# Altium schematic sheet Airlock_Capacitive.SchDoc(Airlock_Capacitive)
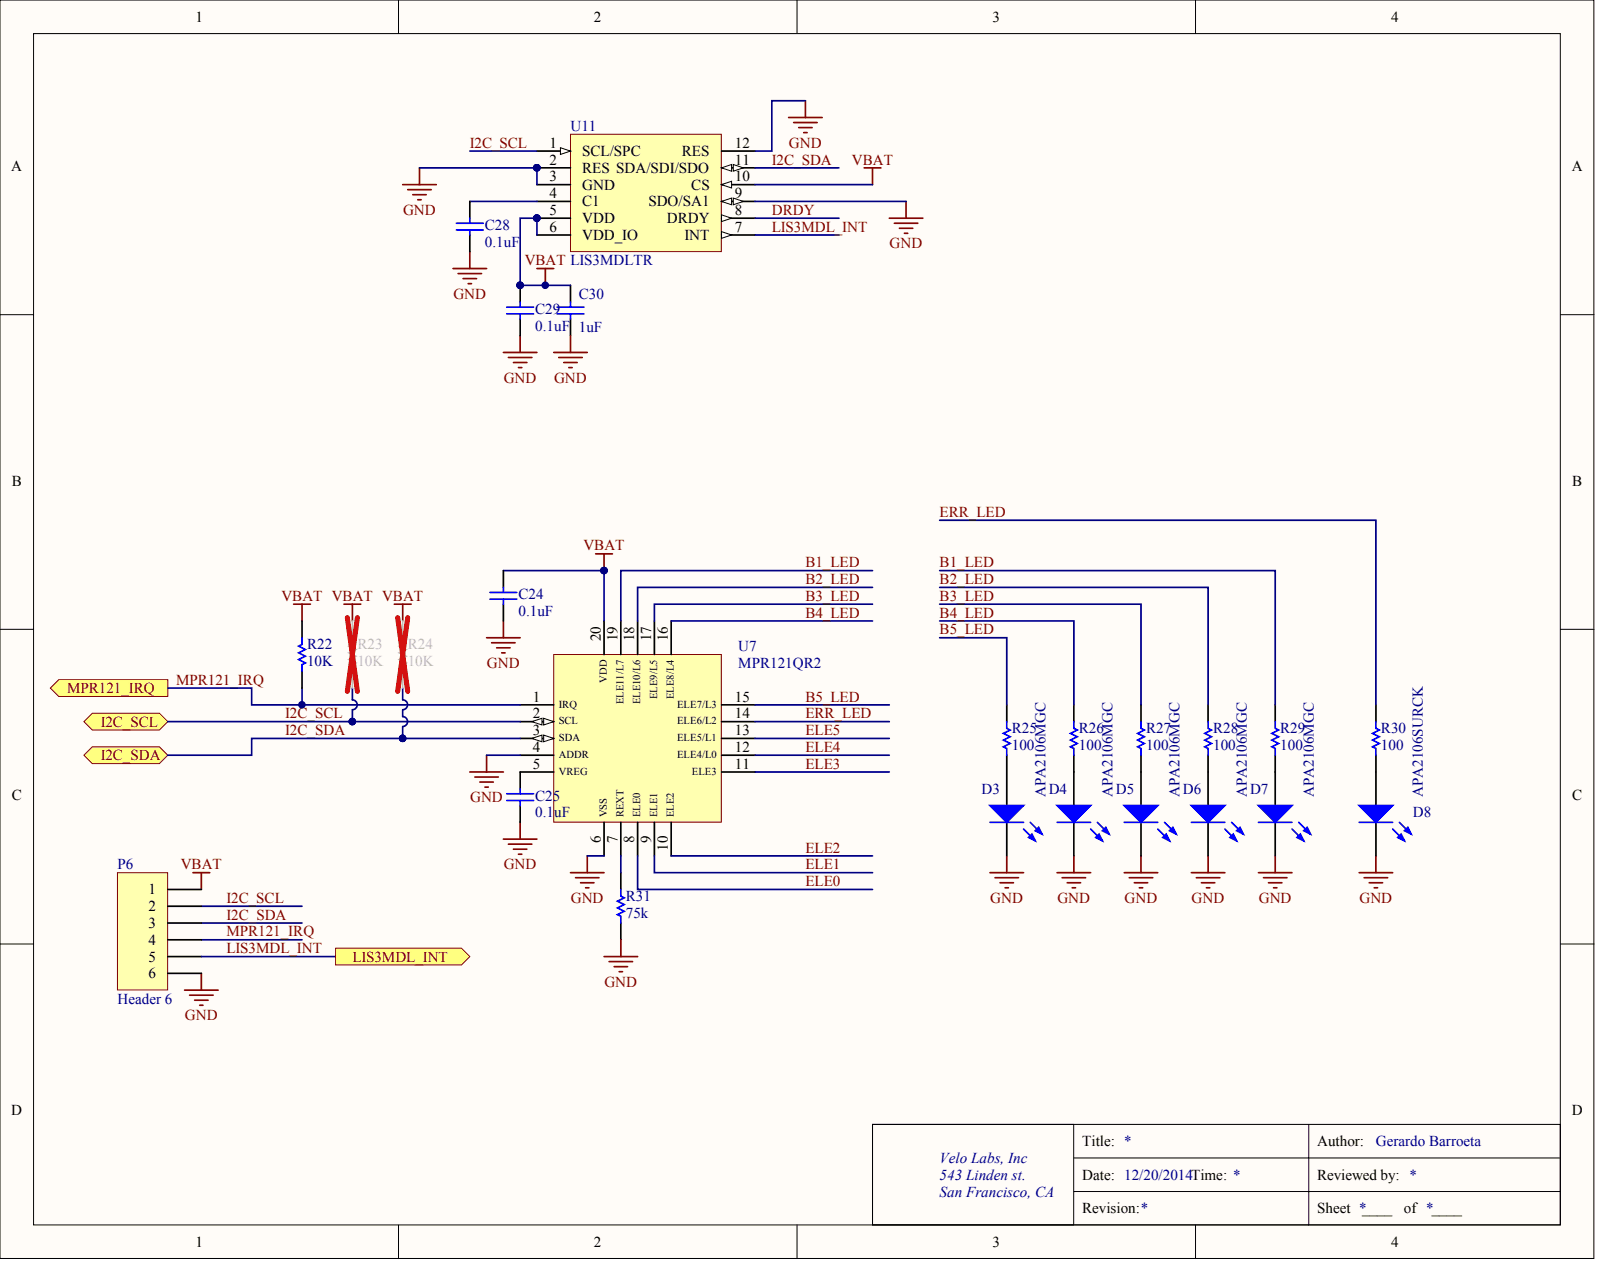
[diagram p0: Airlock_Capacitive.SchDoc]

## Parts
C24  value 0.1uF  (hidden comment: X7R, 16V, 10%)
C25  value 0.1uF  (hidden comment: X7R, 16V, 10%)
C28  value 0.1uF  (hidden comment: X7R, 16V, 10%)
C29  value 0.1uF  (hidden comment: X7R, 16V, 10%)
C30  value 1uF  (hidden comment: X5R, 6.3V 10%)
D3  APA2106MGC
D4  APA2106MGC
D5  APA2106MGC
D6  APA2106MGC
D7  APA2106MGC
D8  APA2106SURCK
P6  Header 6
R22  1%  value 10K
R23  1%  value 10K
R24  1%  value 10K
R25  value 100  (hidden comment: 5% 1/10W)
R26  value 100  (hidden comment: 5% 1/10W)
R27  value 100  (hidden comment: 5% 1/10W)
R28  value 100  (hidden comment: 5% 1/10W)
R29  value 100  (hidden comment: 5% 1/10W)
R30  value 100  (hidden comment: 5% 1/10W)
R31  value 75k  (hidden comment: 5% 1/10W)
U7  MPR121QR2
U11  LIS3MDLTR

## Ports
I2C_SCL, I2C_SDA, LIS3MDL_INT, MPR121_IRQ

## Nets
GND: C24.2 C25.2 C28.2 C29.2 C30.1 D3.2 D4.2 D5.2 D6.2 D7.2 D8.2 P6.6 R31.1 U7.4(ADDR) U7.6(VSS) U11.2(RES) U11.3(GND) U11.9(SDO/SA1) U11.12(RES)
VBAT: C24.1 C29.1 C30.2 P6.1 R22.2 R23.2 R24.2 U7.20(VDD) U11.5(VDD) U11.6(VDD_IO) U11.10(CS)
B1_LED: R29.1 U7.19(ELE11/L7)
B2_LED: R28.1 U7.18(ELE10/L6)
B3_LED: R27.1 U7.17(ELE9/L5)
B4_LED: R26.1 U7.16(ELE8/L4)
B5_LED: R25.1 U7.15(ELE7/L3)
DRDY: U11.8(DRDY)
ELE0: U7.8(ELE0)
ELE1: U7.9(ELE1)
ELE2: U7.10(ELE2)
ELE3: U7.11(ELE3)
ELE4: U7.12(ELE4/L0)
ELE5: U7.13(ELE5/L1)
ERR_LED: R30.1 U7.14(ELE6/L2)
I2C_SCL: P6.2 R23.1 U7.2(SCL) U11.1(SCL/SPC) ; port I2C_SCL
I2C_SDA: P6.3 R24.1 U7.3(SDA) U11.11(SDA/SDI/SDO) ; port I2C_SDA
LIS3MDL_INT: P6.5 U11.7(INT) ; port LIS3MDL_INT
MPR121_IRQ: P6.4 R22.1 U7.1(IRQ) ; port MPR121_IRQ
NetC25_1: C25.1 U7.5(VREG)
NetC28_1: C28.1 U11.4(C1)
NetD3_1: D3.1 R25.2
NetD4_1: D4.1 R26.2
NetD5_1: D5.1 R27.2
NetD6_1: D6.1 R28.2
NetD7_1: D7.1 R29.2
NetD8_1: D8.1 R30.2
NetR31_2: R31.2 U7.7(REXT)

## Library data of the parts
C24 C25 C28 C29 = (no symbol name): Manufacturer: Murata Electronics North America; Manufacturer Part Number: GRM155R71C104KA88D; Description 1: CAP CER 0.1UF 16V 10% X7R 0402; Capacitance: 0.1µF; Supplier 1: Digi-Key; Supplier Part Number 1: 490-3261-1-ND; RoHS: RoHS Compliant; Category: Capacitors; Stock 1: 16410340; Pricing 1: 1=0.1, 10=0.015, 50=0.0082, 100=0.0069, 250=0.00564, 500=0.00482, 1000=0.00375, 5000=0.00313 (USD); Packaging: Cut Tape (CT); Tolerance: ±10%; Voltage - Rated: 16V; Temperature Coefficient: X7R; Mounting Type: Surface Mount, MLCC; Operating Temperature: -55°C ~ 125°C; Applications: General Purpose; Ratings: -; Package / Case: 0402 (1005 Metric); Size / Dimension: 0.039" L x 0.020" W (1.00mm x 0.50mm); Height - Seated (Max): -; Thickness (Max): 0.022" (0.55mm); Lead Spacing: -; Features: -; Lead Style: -; ComponentLink1URL: http://www.murata.com/~/media/webrenewal/support/library/catalog/products/capacitor/mlcc/c02e.ashx; ComponentLink1Description: http://www.murata.com/~/media/webrenewal/support/library/catalog/products/capacitor/mlcc/c02e.ashx
C30 = (no symbol name): Manufacturer: Murata Electronics North America; Manufacturer Part Number: GRM155R60J105KE19D; Description 1: CAP CER 1UF 6.3V 10% X5R 0402; Capacitance: 1.0µF; Applications: General Purpose; Supplier 1: Digi-Key; Supplier Part Number 1: 490-1320-1-ND; RoHS: RoHS Compliant; Category: Capacitors; Stock 1: 2947181; Pricing 1: 1=0.1, 10=0.035, 50=0.0192, 100=0.0163, 250=0.01332, 500=0.01138, 1000=0.0087, 5000=0.00725 (USD); Packaging: Cut Tape (CT); Tolerance: ±10%; Voltage - Rated: 6.3V; Temperature Coefficient: X5R; Mounting Type: Surface Mount, MLCC; Operating Temperature: -55°C ~ 85°C; Ratings: -; Package / Case: 0402 (1005 Metric); Size / Dimension: 0.039" L x 0.020" W (1.00mm x 0.50mm); Height - Seated (Max): -; Thickness (Max): 0.022" (0.55mm); Lead Spacing: -; Features: -; Lead Style: -; ComponentLink1URL: http://www.murata.com/~/media/webrenewal/support/library/catalog/products/capacitor/mlcc/c02e.ashx; ComponentLink1Description: http://www.murata.com/~/media/webrenewal/support/library/catalog/products/capacitor/mlcc/c02e.ashx
D3-D7 = (no symbol name): Manufacturer: Kingbright; Description 1: Standard LEDs - SMD Green 570nm Water Clear 80mcd; Illumination Color: Green; Forward Current: 20 mA; Forward Voltage: 2.1 V; LED Size: 2.1 mm x 0.6 mm x 1 mm; Luminous Intensity: 80 mcd; Viewing Angle: 120 deg; Wavelength/Color Temperature: 570 nm; Supplier 1: Mouser; Manufacturer Part Number: APA2106MGC; RoHS: RoHS Compliant; Category: Standard LEDs - SMD; Stock 1: 1095; Pricing 1: 1=0.675, 10=0.423, 100=0.346, 1000=0.251, 2000=0.211, 10000=0.203, 24000=0.193, 50000=0.192 (AUD); Package / Case: 2.1 mm x 0.6 mm x 1 mm; Packaging: Reel; Maximum Operating Temperature: + 85 C; Minimum Operating Temperature: - 40 C; Product Category: LED SMD Standard; Standard Pack Qty: 2000; RoHS - Mouser: Y; Minimum Order Quantity: 1; Reeling: True; ComponentLink1URL: http://www.kingbrightusa.com/images/catalog/SPEC/APA2106MGC.pdf; ComponentLink1Description: http://www.kingbrightusa.com/images/catalog/SPEC/APA2106MGC.pdf; Supplier Part Number 1: 604-APA2106MGC
D8 = (no symbol name): Manufacturer: Kingbright; Description 1: Standard LEDs - SMD Hyper Red R.A. 635nm, 250mcd; Illumination Color: Red; Forward Current: 20 mA; Forward Voltage: 1.95 V; LED Size: 2.1 mm x 0.6 mm x 1 mm; Luminous Intensity: 250 mcd; Viewing Angle: 120 deg; Wavelength/Color Temperature: 630 nm; Supplier 1: Mouser; Manufacturer Part Number: APA2106SURCK; RoHS: RoHS Compliant; Category: Standard LEDs - SMD; Stock 1: 0; Pricing 1: 1=0.324, 10=0.198, 100=0.147, 1000=0.120, 2000=0.108, 10000=0.099, 24000=0.089, 50000=0.084, 100000=0.080 (AUD); Package / Case: 2.1 mm x 0.6 mm x 1 mm; Packaging: Reel; Maximum Operating Temperature: + 85 C; Minimum Operating Temperature: - 40 C; Product Category: LED SMD Standard; Standard Pack Qty: 2000; RoHS - Mouser: Y; Minimum Order Quantity: 1; Reeling: True; ComponentLink1URL: http://www.kingbrightusa.com/images/catalog/SPEC/APA2106SURCK.pdf; ComponentLink1Description: http://www.kingbrightusa.com/images/catalog/SPEC/APA2106SURCK.pdf; Supplier Part Number 1: 604-APA2106SURCK
R22-R24 = (no symbol name): Manufacturer: Panasonic Electronic Components; Manufacturer Part Number: ERJ-2RKF1002X; Description 1: RES SMD 10K OHM 1% 1/10W 0402; Power (Watts): 0.1W, 1/10W; Temperature Coefficient: ±100ppm/°C; Published: 8-Jun-2000; LatestRevisionDate: 17-Jul-2002; LatestRevisionNote: Re-released for DXP Platform.; PackageReference: AXIAL-0.3; PackageDescription: Axial Device, Thru-Hole; 2 Leads; 0.3 in Pin Spacing; Supplier 1: Digi-Key; Supplier Part Number 1: P10.0KLCT-ND; RoHS: RoHS Compliant; Category: Resistors; Stock 1: 185308; Pricing 1: 1=0.1, 50=0.0196, 100=0.0149, 250=0.01104, 500=0.00816, 1000=0.00544, 5000=0.00383 (USD); Packaging: Cut Tape (CT); Resistance (Ohms): 10k; Tolerance: ±1%; Composition: Thick Film; Features: Automotive AEC-Q200 Qualified; Operating Temperature: -55°C ~ 155°C; Package / Case: 0402 (1005 Metric); Supplier Device Package: 0402; Size / Dimension: 0.039" L x 0.020" W (1.00mm x 0.50mm); Height: 0.016" (0.40mm); Number of Terminations: 2; ComponentLink1URL: http://industrial.panasonic.com/www-cgi/jvcr13pz.cgi?E+PZ+3+AOA0002+ERJ2RKF1002X+7+WW; ComponentLink1Description: http://industrial.panasonic.com/www-cgi/jvcr13pz.cgi?E+PZ+3+AOA0002+ERJ2RKF1002X+7+WW; Publisher: Altium Limited
R25-R30 = (no symbol name): Manufacturer: Panasonic; Manufacturer Part Number: ERJ-2GEJ101X; Description 1: Thick Film Resistors - SMD 0402 100ohms 5% Tol; Power Rating: 100 mW; Resistance: 100 Ohms; Temperature Coefficient: 200 PPM / C; Voltage Rating: 50 V; Supplier 1: Mouser; Supplier Part Number 1: 667-ERJ-2GEJ101X; RoHS: RoHS Compliant By Exemption; Category: Thick Film Resistors - SMD; Stock 1: 211544; Pricing 1: 1=0.257, 10=0.023, 100=0.009, 1000=0.007, 5000=0.004, 10000=0.003 (AUD); Dimensions: 0.5 mm W x 1 mm L x 0.35 mm H; Operating Temperature Range: - 55 C to + 155 C; Package / Case: 0402 (1005 metric); Packaging: Reel; Product: Thick Film Resistors SMD; Series: ERJ; Termination Style: SMD/SMT; Tolerance: 5 %; Case Code - in: 0402; Case Code - mm: 1005; Standard Pack Qty: 10000; RoHS - Mouser: E; Minimum Order Quantity: 1; Reeling: True; ComponentLink1URL: http://industrial.panasonic.com/www-data/pdf/AOA0000/AOA0000CE1.pdf; ComponentLink1Description: http://industrial.panasonic.com/www-data/pdf/AOA0000/AOA0000CE1.pdf
R31 = (no symbol name): Manufacturer: Panasonic Electronic Components; Manufacturer Part Number: ERJ-2RKF7502X; Description 1: RES 75K OHM 1/10W 1% 0402 SMD; Tolerance: ±1%; Power (Watts): 0.1W, 1/10W; Temperature Coefficient: ±100ppm/°C; Supplier 1: Digi-Key; Supplier Part Number 1: P75.0KLDKR-ND; RoHS: RoHS Compliant; Category: Resistors; Stock 1: 273556; Pricing 1: 1=0.1, 50=0.0196, 100=0.0149, 250=0.01104, 500=0.00816, 1000=0.00544, 5000=0.00383 (USD); Packaging: Digi-Reel®; Resistance (Ohms): 75k; Composition: Thick Film; Features: -; Package / Case: 0402 (1005 Metric); Supplier Device Package: 0402; Size / Dimension: 0.039" L x 0.020" W (1.00mm x 0.50mm); Height: 0.016" (0.40mm); Number of Terminations: 2; ComponentLink1URL: http://industrial.panasonic.com/www-cgi/jvcr13pz.cgi?E+PZ+3+AOA0002+ERJ2RKF7502X+7+WW; ComponentLink1Description: http://industrial.panasonic.com/www-cgi/jvcr13pz.cgi?E+PZ+3+AOA0002+ERJ2RKF7502X+7+WW
U7 = (no symbol name): Manufacturer: Freescale Semiconductor; Description 1: IC CTLR TOUCH SENSOR 20-QFN; Number of Inputs/Keys: 12 Key; Evaluation Tools: Available; Data Interface: I²C, Serial; Voltage - Supply: 1.71 V ~ 3.6 V; Current - Supply: 29µA; Supplier 1: Digi-Key; Manufacturer Part Number: MPR121QR2; RoHS: RoHS Compliant; Category: Sensors, Transducers; Stock 1: 9356; Pricing 1: 1=1.2, 10=1.075, 25=1.0104, 100=0.9675, 250=0.92452, 500=0.86 (USD); Packaging: Cut Tape (CT); Type: Capacitive, Proximity Sensor; Touch Panel Interface: -; Resolution (Bits): -; Data Rate/Sampling Rate (SPS, BPS): -; Voltage Reference: -; Operating Temperature: -40°C ~ 85°C; Mounting Type: Surface Mount; Package / Case: 20-UFQFN; Supplier Device Package: 20-QFN (3x3); ComponentLink1URL: http://www.freescale.com/files/sensors/doc/data_sheet/MPR121.pdf; ComponentLink1Description: http://www.freescale.com/files/sensors/doc/data_sheet/MPR121.pdf; Supplier Part Number 1: MPR121QR2CT-ND
U11 = (no symbol name): Manufacturer: STMicroelectronics; Description 1: IC MAGNETOMETER 3-AXIS 12LGA; Sensing Range: ±4, 8, 12, 16 gauss; Voltage - Supply: 1.9 V ~ 3.6 V; Output Type: I²C, SPI; Supplier 1: Digi-Key; Manufacturer Part Number: LIS3MDLTR; RoHS: RoHS Compliant; Category: Sensors, Transducers; Stock 1: 0; Pricing 1: 8000=0.7293, 16000=0.70125, 24000=0.69003 (USD); Packaging: *; Type: *; Current - Supply: -; Current - Output (Max): -; Features: *; Operating Temperature: -40°C ~ 85°C; Package / Case: 12-VFLGA; Supplier Device Package: *; ComponentLink1URL: http://www.st.com/web/en/resource/technical/document/datasheet/DM00075867.pdf; ComponentLink1Description: http://www.st.com/web/en/resource/technical/document/datasheet/DM00075867.pdf; Supplier Part Number 1: 497-13892-2-ND
P6 = (no symbol name): LatestRevisionDate: 17-Jul-2002; LatestRevisionNote: Re-released for DXP Platform.; Publisher: Altium Limited
